# Altium schematic sheet 01_CapacitiveIgnitionTachometer.SchDoc(01_CapacitiveIgnitionTachometer)
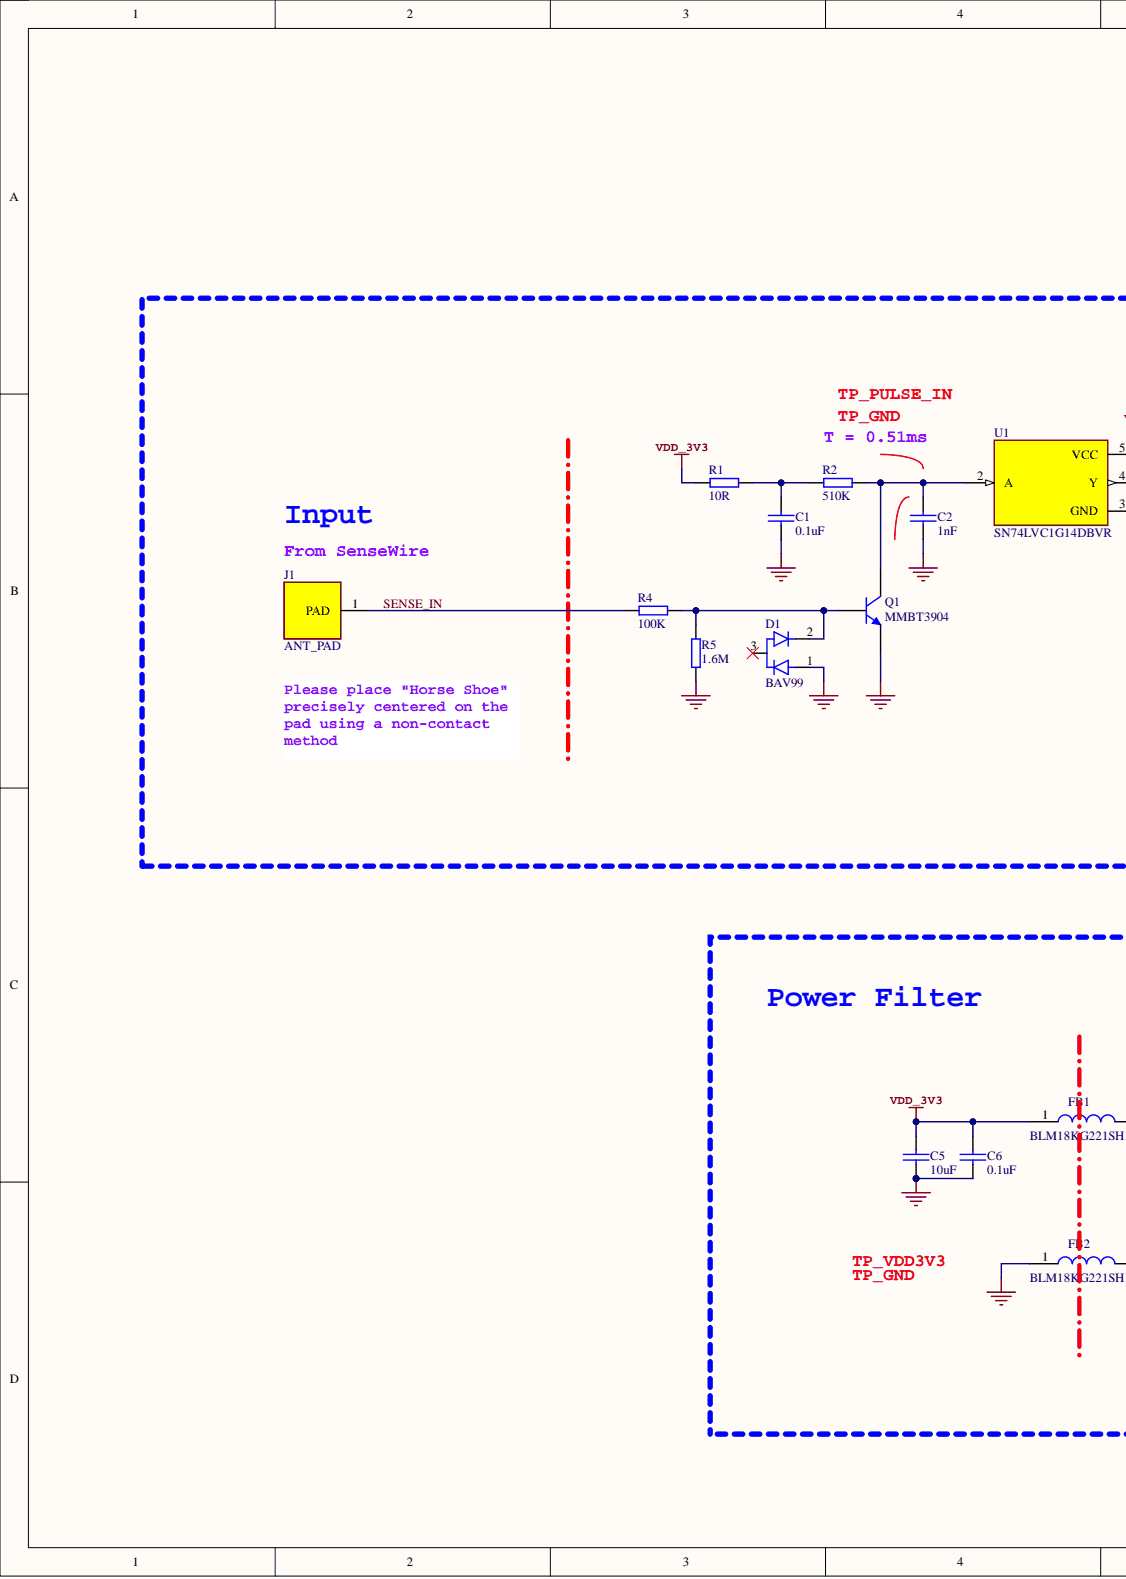
[diagram p0: 01_CapacitiveIgnitionTachometer.SchDoc, left]
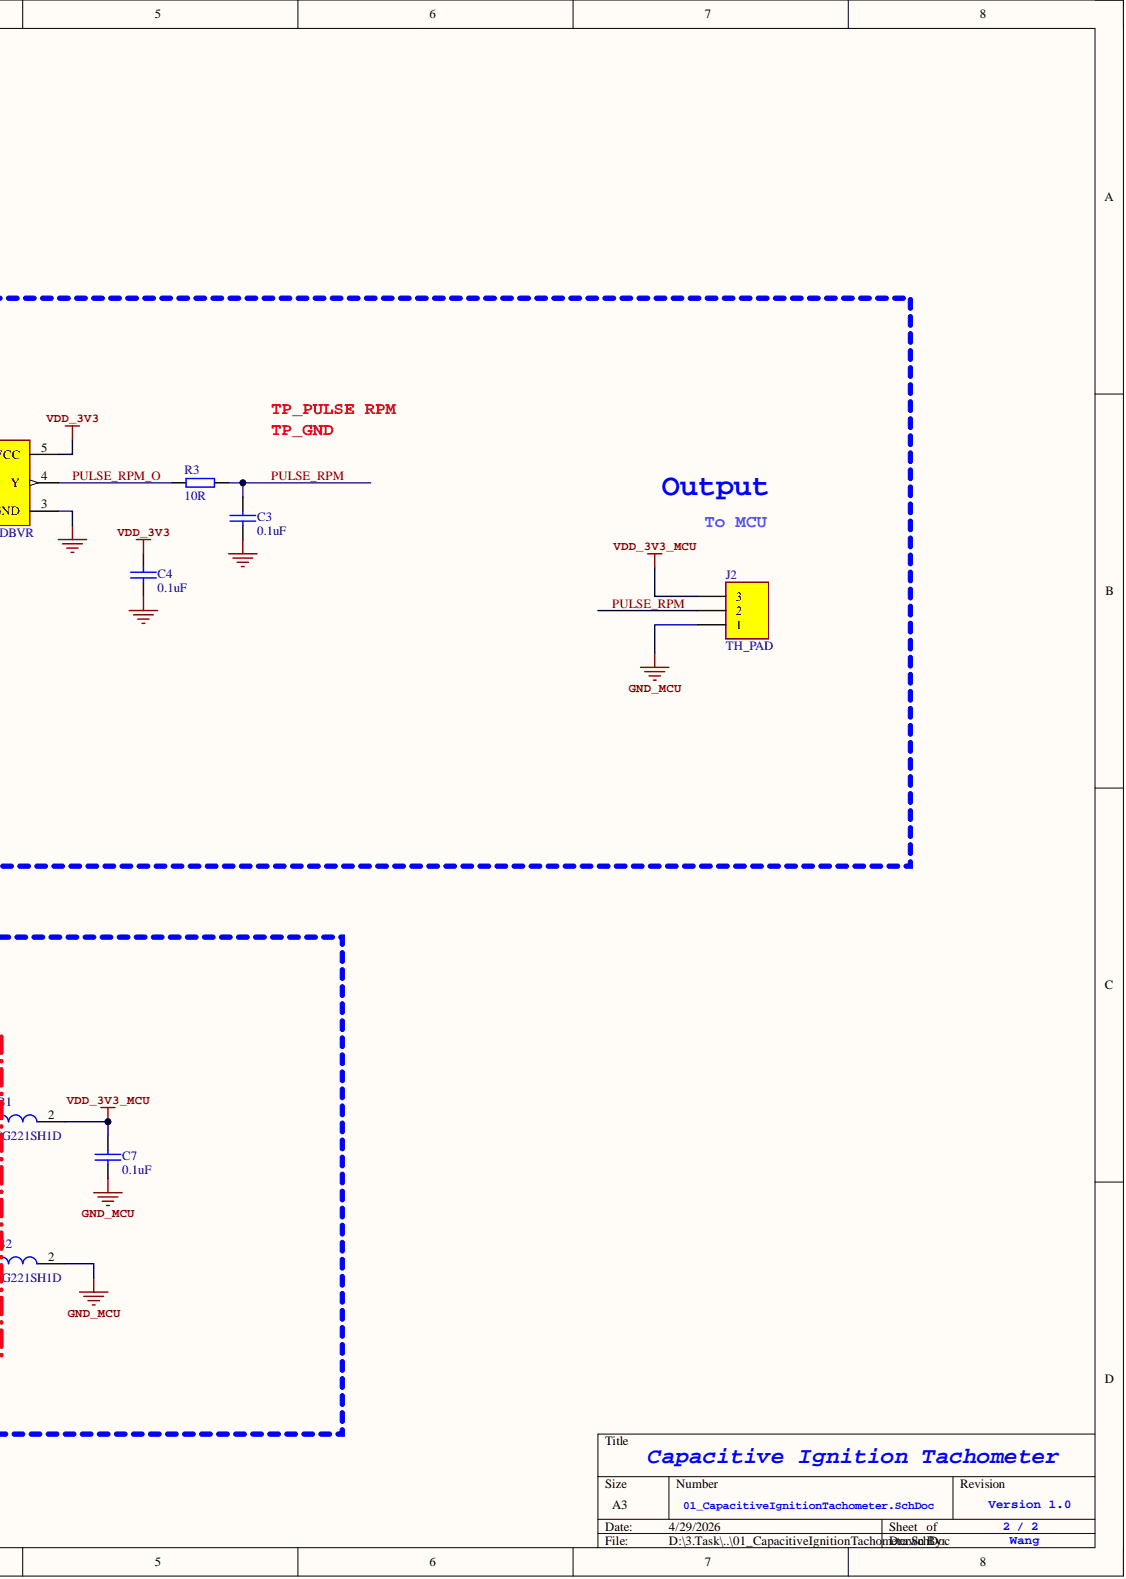
[diagram p1: 01_CapacitiveIgnitionTachometer.SchDoc, right]

## Parts
C1  value 0.1uF  [C0603]  (hidden comment: GRM188R71H104KA93D)
C2  value 1nF  [C0603]  (hidden comment: GRM1885C1H102JA01D)
C3  value 0.1uF  [C0603]  (hidden comment: GRM188R71H104KA93D)
C4  value 0.1uF  [C0603]  (hidden comment: GRM188R71H104KA93D)
C5  value 10uF  [C0805]  (hidden comment: GRM21BR61H106KE43L)
C6  value 0.1uF  [C0603]  (hidden comment: GRM188R71H104KA93D)
C7  value 0.1uF  [C0603]  (hidden comment: GRM188R71H104KA93D)
D1  BAV99  [SOT23]
FB1  BLM18KG221SH1D  [L0603]
FB2  BLM18KG221SH1D  [L0603]
J1  ANT_PAD  [ANT_PAD]
J2  TH_PAD  [3PIN_2.54_NO3D]
Q1  MMBT3904  [SOT23]
R1  value 10R  [R0603]  (hidden comment: RC0603FR-0710RL)
R2  value 510K  [R0603]  (hidden comment: RC0603FR-07510KL)
R3  value 10R  [R0603]  (hidden comment: RC0603FR-0710RL)
R4  value 100K  [R0805]  (hidden comment: RT0805BRD07100KL)
R5  value 1.6M  [R0805]  (hidden comment: FRC0805F1604TS)
U1  SN74LVC1G14DBVR  [SOT95P280X145-5N]

## Nets
GND: C1.1 C2.1 C3.1 C4.1 C5.1 C6.1 D1.1 FB2.1 Q1.2 R5.2 U1.3(GND)
GND_MCU: C7.1 FB2.2 J2.1
VDD_3V3: C4.2 C5.2 C6.2 FB1.1 R1.1 U1.5(VCC)
VDD_3V3_MCU: C7.2 FB1.2 J2.3
PULSE_RPM: C3.2 J2.2 R3.2
PULSE_RPM_O: R3.1 U1.4(Y)
SENSE_IN: J1.1 R4.1
NetC1_2: C1.2 R1.2 R2.1
NetC2_2: C2.2 Q1.3 R2.2 U1.2(A)
NetD1_2: D1.2 Q1.1 R4.2 R5.1

Not connected: D1 3

## Library data of the parts
C1 C3 C4 C6 C7 = CAP_1uF: 100nF ±10% 50V; Part Number: C77055; Component Kind: Standard; Price: 0.1; URL: https://www.digikey.com/products/en?keywords=311-1447-1-ND; Library Name: Sample.SCHLIB
C2 = CAP_1uF: 1nF ±5% 50V; Part Number: C77026; Component Kind: Standard; Price: 0.1; URL: https://www.digikey.com/products/en?keywords=311-1447-1-ND; Library Name: Sample.SCHLIB
C5 = CAP_1uF: 10uF ±10% 50V; Part Number: C440198; Component Kind: Standard; Price: 0.1; URL: https://www.digikey.com/products/en?keywords=311-1447-1-ND; Library Name: Sample.SCHLIB
D1 = BAV99: Diode Array 1 Pair Series Connection Standard 100V 215mA (DC) Surface Mount TO-236-3, SC-59, SOT-23-3; Part Number: C7420336; MF: ON Semiconductor; Availability: In Stock; Check_prices: https://www.snapeda.com/parts/BAV99/Onsemi/view-part/?ref=eda; Component Kind: Standard; Library Name: BAV99.SchLib; MP: BAV99; Package: SOT-23-3 Motorola; Price: None; Purchase-URL: https://pricing.snapeda.com/search/part/BAV99/?ref=eda; SnapEDA_Link: https://www.snapeda.com/parts/BAV99/Onsemi/view-part/?ref=snap
FB1 FB2 = DFE322512F-2R2M=P2: Single 0603 magnetic bead impedance 220Ω @100MHz; Part Number: C527991; Component Kind: Standard; Value: BLM18KG221SH1D
J1 = 1447009-8: ANT_PAD, 10*10mm, No-Mount; Part Number: C5163200; Availability: Unavailable; Component Kind: Standard; MP: 1447009-8; Package: None; Price: None; Library Name: Capacitive Ignition Tachometer.SCHLIB
J2 = Header 3_1: TH_PAD, No Mount; Part Number: C22472020; Component Kind: Standard; LatestRevisionDate: 17-Jul-2002; LatestRevisionNote: Re-released for DXP Platform.; Library Name: TANK-CARD_1.SCHLIB; Signal Integrity: Connector; Publisher: Altium Limited
Q1 = NPN: hFE: 100-300, Silk Screen: 1AM NPN Current: 200mA Voltage: 40V; Part Number: C7420353; Component Kind: Standard; LatestRevisionDate: 17-Jul-2002; LatestRevisionNote: Re-released for DXP Platform.; PackageReference: TO-226; Price: 0.15; Published: 8-Jun-2000; Signal Integrity: NPN; Simulation: NPN; URL: https://www.digikey.com/products/en?keywords=1727-2919-1-ND; Library Name: Sample.SCHLIB
R1 R3 = RES_4.7K: Thick film resistance 10Ω ±1% 100mW; Part Number: C109318; Component Kind: Standard; Price: 0.1; URL: https://www.digikey.com/products/en?keywords=311-5.1KGRCT-ND; Library Name: Sample.SCHLIB
R2 = RES_4.7K: Thick film resistance 510kΩ ±1% 100mW; Part Number: C114066; Component Kind: Standard; Price: 0.1; URL: https://www.digikey.com/products/en?keywords=311-5.1KGRCT-ND; Library Name: Sample.SCHLIB
R4 = RES_4.7K: Film resistance 100kΩ ±0.1% 125mW; Part Number: C122537; Component Kind: Standard; Price: 0.1; URL: https://www.digikey.com/products/en?keywords=311-5.1KGRCT-ND; Library Name: Sample.SCHLIB
R5 = RES_4.7K: 1.6MΩ ±1% 125mW thick film resistor; Part Number: C5121530; Component Kind: Standard; Price: 0.1; URL: https://www.digikey.com/products/en?keywords=311-5.1KGRCT-ND; Library Name: Sample.SCHLIB
U1 = SN74LVC1G14DBVR: Single 1.65-V to 5.5-V inverter with Schmitt-Trigger inputs; Part Number: C7835; MF: Texas Instruments; Check_prices: https://www.snapeda.com/parts/SN74LVC1G14DBVR/Texas+Instruments/view-part/?ref=eda; Component Kind: Standard; Library Name: SN74LVC1G14DBVR.SchLib; MP: SN74LVC1G14DBVR; Package: SOT-23-5 Texas Instruments; SnapEDA_Link: https://www.snapeda.com/parts/SN74LVC1G14DBVR/Texas+Instruments/view-part/?ref=snap
